# Altium schematic sheet PowerSupply.SchDoc(PowerSupply)
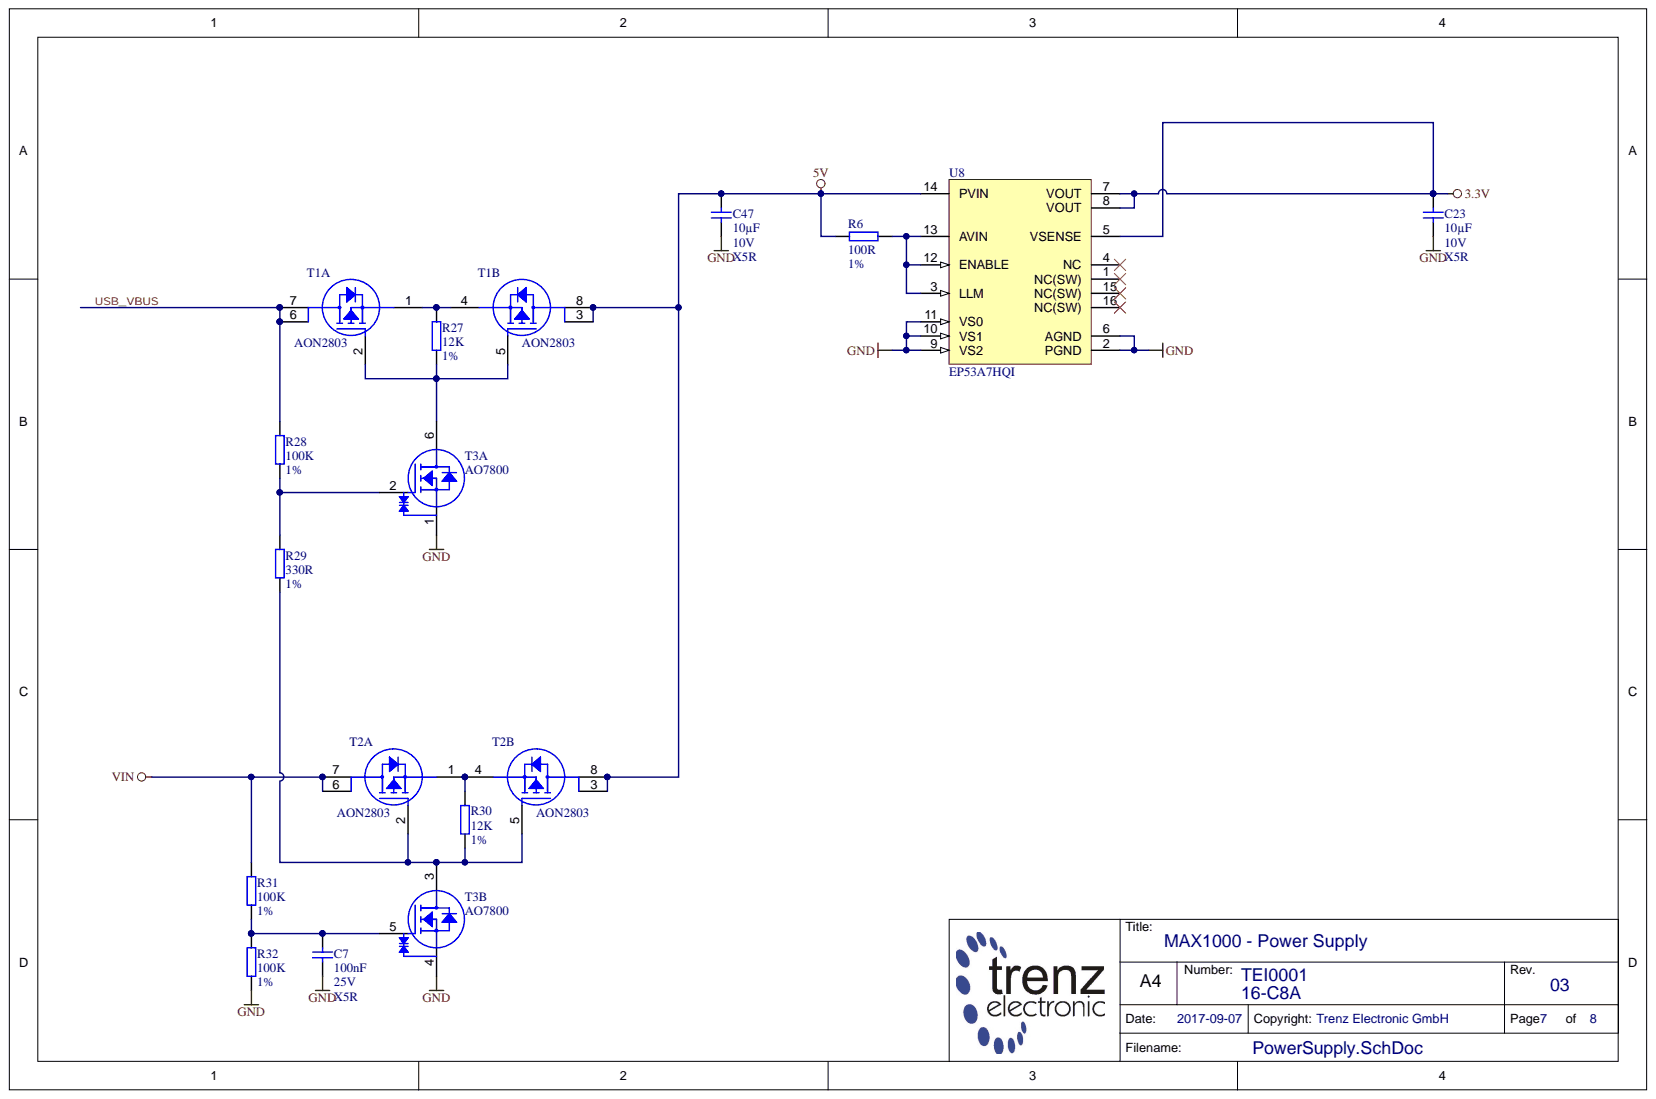
[diagram p0: PowerSupply.SchDoc]

## Parts
C7  100nF  Technology: X5R  Voltage: 25V  [CAPC1005X55N]
C23  10µF  Technology: X5R  Voltage: 10V  [CAPC2012X135N]
C47  10µF  Technology: X5R  Voltage: 10V  [CAPC2012X135N]
R6  100R  Tolerance: 1%  [RESC1005X40N]
R27  12K  Tolerance: 1%  [RESC1005X40N]
R28  100K  Tolerance: 1%  [RESC1005X40N]
R29  330R  Tolerance: 1%  [RESC1005X40N]
R30  12K  Tolerance: 1%  [RESC1005X40N]
R31  100K  Tolerance: 1%  [RESC1005X40N]
R32  100K  Tolerance: 1%  [RESC1005X40N]
T1  AON2803  [SON65P200X200X80-8T10X06]  (parts A,B)
T2  AON2803  [SON65P200X200X80-8T10X06]  (parts A,B)
T3  AO7800  [SOT65P210X110-6N]  (parts A,B)
U8  EP53A7HQI  [EP53F8QI]

## Nets
3.3V: C23.1 U8.5(VSENSE) U8.7(VOUT) U8.8(VOUT)
5V: C47.1 R6.1 T1.3 T1.8 T2.3 T2.8 U8.14(PVIN)
GND: C7.1 C23.2 C47.2 R32.2 T3.1 T3.4 U8.2(PGND) U8.6(AGND) U8.9(VS2) U8.10(VS1) U8.11(VS0)
VIN: R31.1 T2.6 T2.7
USB_VBUS: R28.1 T1.6 T1.7
NetC7_2: C7.2 R31.2 R32.1 T3.5
NetR6_2: R6.2 U8.3(LLM) U8.12(ENABLE) U8.13(AVIN)
NetR27_1: R27.1 T1.2 T1.5 T3.6
NetR27_2: R27.2 T1.1 T1.4
NetR28_2: R28.2 R29.2 T3.2
NetR29_1: R29.1 R30.1 T2.2 T2.5 T3.3
NetR30_2: R30.2 T2.1 T2.4

Not connected: U8 1(NC(SW)) 4(NC) 15(NC(SW)) 16(NC(SW))

## Library data of the parts
C7 = 22472: KON 100nF 25V X5R SMD ±10%; Database Table Name: C_1005_0402; Mixed: SMT; Preferred: Yes; Value: 100n; AN: 22472; Case: 1005 (0402); Component Kind: Standard; Library Name: TE.DbLib
C23 C47 = 28014: KON 10µF 10V X5R 10% SMD; Database Table Name: C_2012_0805; Mixed: SMT; Value: 10u; AN: 28014; Case: 2012 (0805); Component Kind: Standard; Library Name: TE.DbLib; Tolerance: 10%
R6 = 22507: WID SMD 100R 1% 0,063W; Database Table Name: R_1005_0402; Mixed: SMT; Preferred: Yes; Value: 100; AN: 22507; Case: 1005 (0402); Component Kind: Standard; Library Name: TE.DbLib; Technology: TK100
R27 R30 = 22598: WID SMD 12K 1% 0,063W; Database Table Name: R_1005_0402; Mixed: SMT; Preferred: Yes; AN: 22598; Case: 1005 (0402); Component Kind: Standard; Library Name: TE.DbLib; Technology: TK100; Value: 12K
R28 R31 R32 = 22558: WID SMD 100K 1% 0,063W; Database Table Name: R_1005_0402; Mixed: SMT; Preferred: Yes; AN: 22558; Case: 1005 (0402); Component Kind: Standard; Library Name: TE.DbLib; Technology: TK100; Value: 100K
R29 = 22762: WID SMD 330R 1% 0,063W; Database Table Name: R_1005_0402; Mixed: SMT; Preferred: Yes; Value: 330; AN: 22762; Case: 1005 (0402); Component Kind: Standard; Library Name: TE.DbLib; Technology: TK100
T1 T2 = 28041: Database Table Name: TRANSISTOR; Mixed: SMT; Value: -3.8A; Voltage: -20V; AN: 28041; Case: DFN6; Component Kind: Standard; Description: AON2803; H-ART: AON2803; Library Name: TE.DbLib; HelpURL: b:\AD\adlib\datasheet\AON2803\
T3 = 28043: Database Table Name: TRANSISTOR; Mixed: SMT; Value: 0.9A; Voltage: 20V; AN: 28043; Case: SOT23-6; Component Kind: Standard; Description: AO7800; H-ART: AO7800; Library Name: TE.DbLib; HelpURL: b:\AD\adlib\datasheet\AO7800\
U8 = 27996: Database Table Name: DCDC; Mixed: SMT; Preferred: Yes; AN: 27996; Case: QFN16; Component Kind: Standard; Description: EP53A7HQI; H-ART: EP53A7HQI; Library Name: TE.DbLib; Trenz-Stock: Yes; HelpURL: B:\AD\adlib\datasheet\EP53A7HQI
